# Altium schematic sheet P05_Ethernet.SchDoc
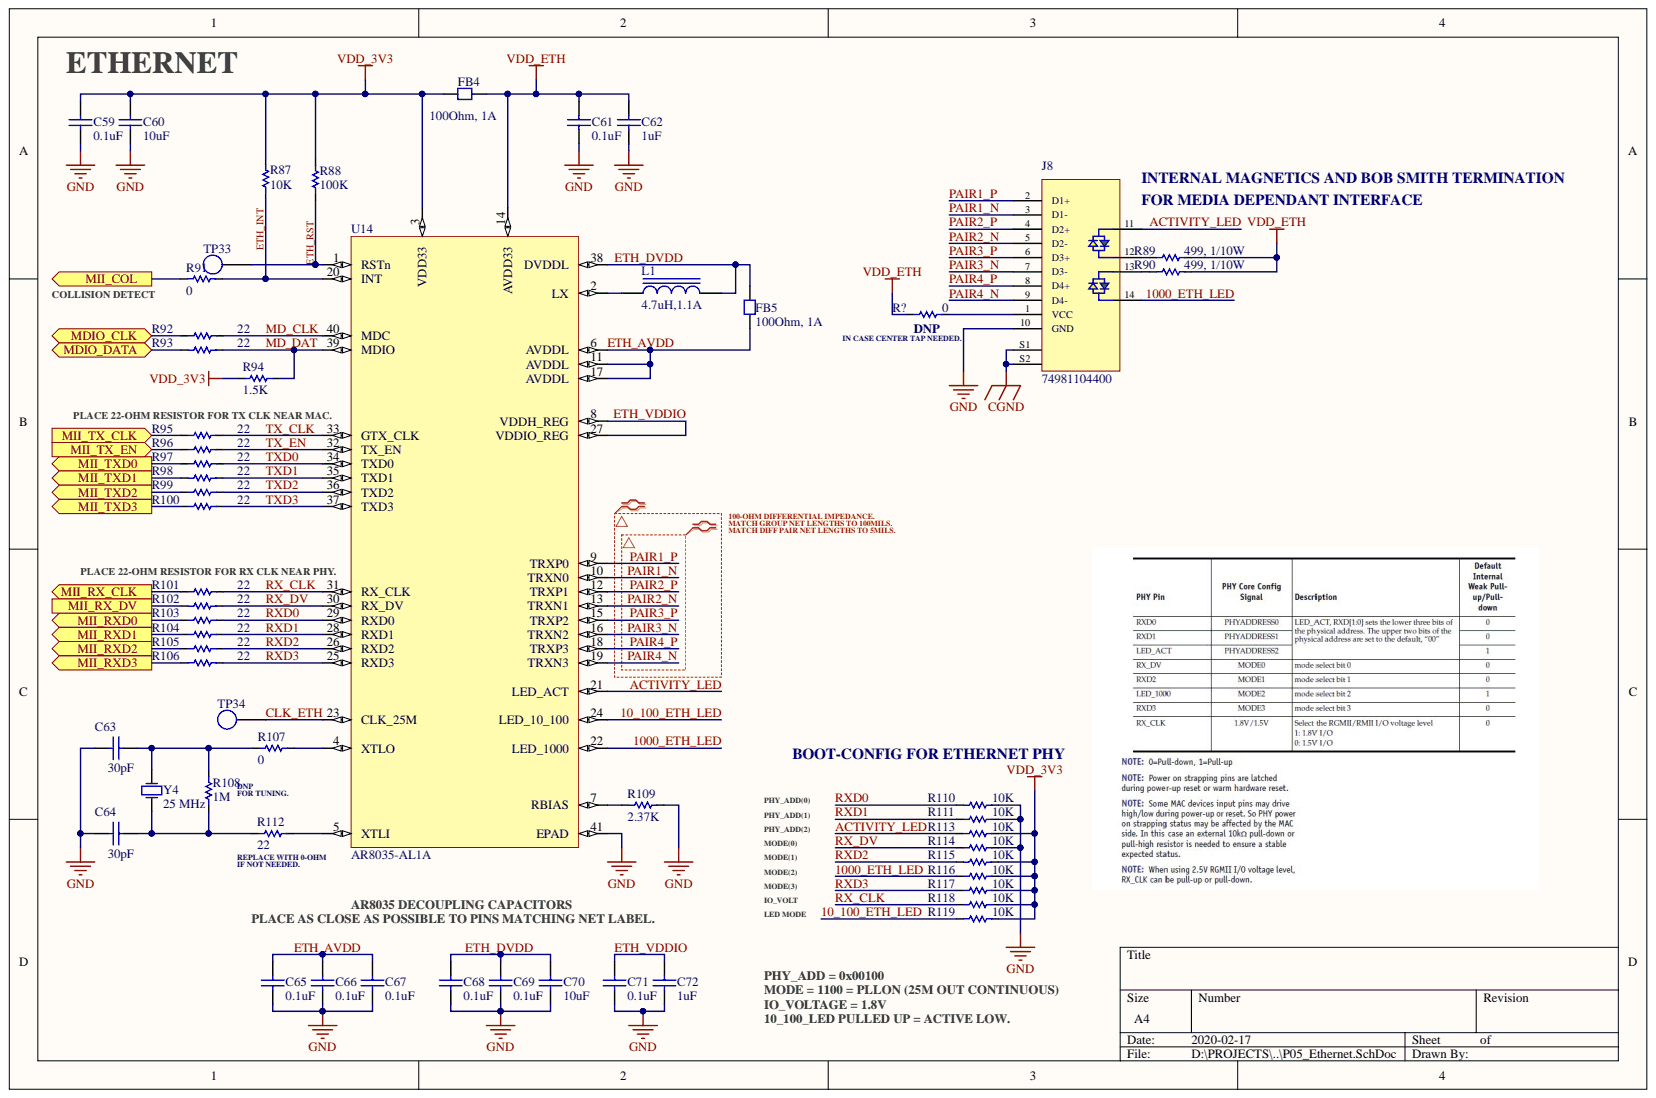
[diagram p0: P05_Ethernet.SchDoc]

## Parts
C59  0.1uF  [CAP_0603]
C60  10uF  [CAP_0603]
C61  0.1uF  [CAP_0603]
C62  1uF  [CAP_0603]
C63  30pF  [CAP_0603]
C64  30pF  [CAP_0603]
C65  0.1uF  [CAP_0603]
C66  0.1uF  [CAP_0603]
C67  0.1uF  [CAP_0603]
C68  0.1uF  [CAP_0603]
C69  0.1uF  [CAP_0603]
C70  10uF  [CAP_0603]
C71  0.1uF  [CAP_0603]
C72  1uF  [CAP_0603]
FB4  100Ohm, 1A  [CAP_0603]
FB5  100Ohm, 1A  [CAP_0603]
J8  74981104400  [74981104400]
L1  4.7uH,1.1A  [IND_1008]
R87  10K  [RES_0603]
R88  100K  [RES_0603]
R89  499, 1/10W  Power Rating: 1/10W  [RES_0603]
R90  499, 1/10W  Power Rating: 1/10W  [RES_0603]
R91  0  [RES_0603]
R92  22  [RES_0603]
R93  22  [RES_0603]
R94  1.5K  [RES_0603]
R95  22  [RES_0603]
R96  22  [RES_0603]
R97  22  [RES_0603]
R98  22  [RES_0603]
R99  22  [RES_0603]
R100  22  [RES_0603]
R101  22  [RES_0603]
R102  22  [RES_0603]
R103  22  [RES_0603]
R104  22  [RES_0603]
R105  22  [RES_0603]
R106  22  [RES_0603]
R107  0  [RES_0603]
R108  1M  [RES_0603]
R109  2.37K  [RES_0603]
R110  10K  [RES_0603]
R111  10K  [RES_0603]
R112  22  [RES_0603]
R113  10K  [RES_0603]
R114  10K  [RES_0603]
R115  10K  [RES_0603]
R116  10K  [RES_0603]
R117  10K  [RES_0603]
R118  10K  [RES_0603]
R119  10K  [RES_0603]
R?  0  [RES_0603]
TP33  TP_SMD  [TP_SMD]  (value not drawn)
TP34  TP_SMD  [TP_SMD]  (value not drawn)
U14  AR8035-AL1A  [QFN-40_5X5MM]
Y4  25 MHz  [7A-24MHz]

## Ports
MDIO_CLK, MDIO_DATA, MII_COL, MII_RX_CLK, MII_RX_DV, MII_RXD0, MII_RXD1, MII_RXD2, MII_RXD3, MII_TX_CLK, MII_TX_EN, MII_TXD0, MII_TXD1, MII_TXD2, MII_TXD3

## Nets
CGND: J8.S1 J8.S2
GND: C59.1 C60.1 C61.1 C62.1 C63.1 C64.1 C65.1 C66.1 C67.1 C68.1 C69.1 C70.1 C71.1 C72.1 J8.10(GND) R109.2 R110.2 R111.2 R114.2 U14.41(EPAD)
VDD_3V3: C59.2 C60.2 FB4.1 R87.2 R88.2 R94.2 R113.2 R115.2 R116.2 R117.2 R118.2 R119.2 U14.3(VDD33)
VDD_ETH: C61.2 C62.2 FB4.2 R89.2 R90.2 R?.1 U14.14(AVDD33)
10_100_ETH_LED: R119.1 U14.24(LED_10_100)
1000_ETH_LED: J8.14 R116.1 U14.22(LED_1000)
ACTIVITY_LED: J8.11 R113.1 U14.21(LED_ACT)
CLK_ETH: TP34.0 U14.23(CLK_25M)
ETH_AVDD: C65.2 C66.2 C67.2 FB5.1 U14.6(AVDDL) U14.11(AVDDL) U14.17(AVDDL)
ETH_DVDD: C68.2 C69.2 C70.2 FB5.2 L1.2 U14.38(DVDDL)
ETH_INT: R87.1 R91.2 U14.20(INT)
ETH_RST: R88.1 TP33.0 U14.1(RSTn)
ETH_VDDIO: C71.2 C72.2 U14.8(VDDH_REG) U14.27(VDDIO_REG)
MD_CLK: R92.2 U14.40(MDC)
MD_DAT: R93.2 R94.1 U14.39(MDIO)
MDIO_CLK: R92.1 ; port MDIO_CLK
MDIO_DATA: R93.1 ; port MDIO_DATA
MII_COL: R91.1 ; port MII_COL
MII_RX_CLK: R101.1 ; port MII_RX_CLK
MII_RX_DV: R102.1 ; port MII_RX_DV
MII_RXD0: R103.1 ; port MII_RXD0
MII_RXD1: R104.1 ; port MII_RXD1
MII_RXD2: R105.1 ; port MII_RXD2
MII_RXD3: R106.1 ; port MII_RXD3
MII_TX_CLK: R95.1 ; port MII_TX_CLK
MII_TX_EN: R96.1 ; port MII_TX_EN
MII_TXD0: R97.1 ; port MII_TXD0
MII_TXD1: R98.1 ; port MII_TXD1
MII_TXD2: R99.1 ; port MII_TXD2
MII_TXD3: R100.1 ; port MII_TXD3
PAIR1_N: J8.3(D1-) U14.10(TRXN0)
PAIR1_P: J8.2(D1+) U14.9(TRXP0)
PAIR2_N: J8.5(D2-) U14.13(TRXN1)
PAIR2_P: J8.4(D2+) U14.12(TRXP1)
PAIR3_N: J8.7(D3-) U14.16(TRXN2)
PAIR3_P: J8.6(D3+) U14.15(TRXP2)
PAIR4_N: J8.9(D4-) U14.19(TRXN3)
PAIR4_P: J8.8(D4+) U14.18(TRXP3)
RX_CLK: R101.2 R118.1 U14.31(RX_CLK)
RX_DV: R102.2 R114.1 U14.30(RX_DV)
RXD0: R103.2 R110.1 U14.29(RXD0)
RXD1: R104.2 R111.1 U14.28(RXD1)
RXD2: R105.2 R115.1 U14.26(RXD2)
RXD3: R106.2 R117.1 U14.25(RXD3)
TX_CLK: R95.2 U14.33(GTX_CLK)
TX_EN: R96.2 U14.32(TX_EN)
TXD0: R97.2 U14.34(TXD0)
TXD1: R98.2 U14.35(TXD1)
TXD2: R99.2 U14.36(TXD2)
TXD3: R100.2 U14.37(TXD3)
NetC63_2: C63.2 R107.1 R108.2 Y4.2
NetC64_2: C64.2 R108.1 R112.1 Y4.1
NetJ8_1: J8.1(VCC) R?.2
NetJ8_12: J8.12 R89.1
NetJ8_13: J8.13 R90.1
NetL1_1: L1.1 U14.2(LX)
NetR107_2: R107.2 U14.4(XTLO)
NetR109_1: R109.1 U14.7(RBIAS)
NetR112_2: R112.2 U14.5(XTLI)
N00356:
N00357:

## Library data of the parts
C59 C61 C65-C69 C71 = C0603C104M4RACTU: CAP CER 0.1UF 16V X7R 0603; Manufacturer: KEMET; Tolerance: 20%; Ceramic Type: X7R; Component Kind: Standard; ComponentLink1Description: Manufacturer; ComponentLink1URL: http://www.we-online.de; ComponentLink2Description: Datasheet; ComponentLink2URL: https://content.kemet.com/datasheets/KEM_C1002_X7R_SMD.pdf; Datasheet: https://content.kemet.com/datasheets/KEM_C1002_X7R_SMD.pdf; Manufacturer Part No.: C0603C104M4RACTU; Mounting Technology: SMD; Package Description: 0603; Package Reference: CAP_0603; Rated Voltage: 16V; Supplier: Digi-Key; Supplier Part No.: 399-1099-1-ND; Value: 0.1uF; Library Name: OSD335-X_Devboard.SchLib
C60 C70 = C0603C106M8PAC7867: CAP CER 10UF 10V X5R 0603; Manufacturer: KEMET; Ceramic Type: X7R; Tolerance: 20%; Component Kind: Standard; ComponentLink1Description: Manufacturer; ComponentLink1URL: http://www.we-online.de; ComponentLink2Description: Datasheet; ComponentLink2URL: https://content.kemet.com/datasheets/KEM_C1002_X7R_SMD.pdf; Datasheet: https://content.kemet.com/datasheets/KEM_C1002_X7R_SMD.pdf; Manufacturer Part No.: C0603C106M8PAC7867; Mounting Technology: SMD; Package Description: 0603; Package Reference: CAP_0603; Rated Voltage: 10V; Supplier: Digi-Key; Supplier Part No.: 399-14945-1-ND; Value: 10uF; Library Name: OSD335-X_Devboard.SchLib
C62 C72 = C0603C105M4RACTU: CAP CER 1UF 16V X7R 0603; Manufacturer: KEMET; Tolerance: 20%; Ceramic Type: X7R; Component Kind: Standard; ComponentLink1Description: Manufacturer; ComponentLink1URL: http://www.we-online.de; ComponentLink2Description: Datasheet; ComponentLink2URL: https://content.kemet.com/datasheets/KEM_C1002_X7R_SMD.pdf; Datasheet: https://content.kemet.com/datasheets/KEM_C1002_X7R_SMD.pdf; Manufacturer Part No.: C0603C105M4RACTU; Mounting Technology: SMD; Package Description: 0603; Package Reference: CAP_0603; Rated Voltage: 16V; Supplier: Digi-Key; Supplier Part No.: 399-11136-1-ND; Value: 1uF; Library Name: OSD335-X_Devboard.SchLib
C63 C64 = 06035A300JAT2A: CAP CER 30PF 50V C0G/NP0 0603; Manufacturer: AVX Corporation; Rated Voltage: 50 VDC; Tolerance: 5%; Component Kind: Standard; ComponentLink1Description: Manufacturer; ComponentLink1URL: http://www.we-online.de; ComponentLink2Description: Datasheet; ComponentLink2URL: https://katalog.we-online.com/pbs/datasheet/865080345012.pdf; Manufacturer Part No.: 06035A300JAT2A; Mounting Technology: SMD; Package Description: 0603; Package Reference: CAP_0603; Supplier: Digi-Key; Supplier Part No.: 478-7917-1-ND; Value: 30 pF; Library Name: OSD335-X_Devboard.SchLib
FB4 FB5 = MPZ1608D101BTA00: FERRITE BEAD 100 OHM 0603 1LN; Manufacturer: TDK Corporation; Component Kind: Standard; Datasheet: https://product.tdk.com/info/en/catalog/datasheets/beads_commercial_power_mpz1608_en.pdf; Manufacturer Part No.: MPZ1608D101BTA00; Mounting Technology: SMD; Package Description: 100 Ohms @ 100MHz 1 Power Line Ferrite Bead 0603 (1608 Metric) 1A 150mOhm; Package Reference: CAP_0603; Supplier: Digi-Key; Supplier Part No.: 445-2988-1-ND; Library Name: OSD335-X_Devboard.SchLib
J8 = 74981104400: WE-RJ45LAN INTEGRATED RJ45 TRANS; Manufacturer: Wurth Elektronik; Component Kind: Standard; ComponentLink1Description: Manufacturer; ComponentLink1URL: http://www.we-online.de; ComponentLink2Description: Datasheet; ComponentLink2URL: https://katalog.we-online.com/pbs/datasheet/74981104400.pdf; Manufacturer Part No.: 74981104400; Mounting Technology: SMD; Package Description: 1 Port RJ45 Magjack Connector Through Hole 10/100/1000 Base-T, AutoMDIX; Package Reference: 7498110440; Supplier: Digi-Key; Supplier Part No.: 732-13940-1-ND; Library Name: OSD335-X_Devboard.SchLib
L1 = CVH252009-4R7M: FIXED IND 4.7UH 1.1A 110MOHM SMD; Manufacturer: Bourns Inc.; Tolerance: 20%; Component Kind: Standard; ComponentLink1Description: Datasheet; ComponentLink1URL: https://www.bourns.com/docs/Product-Datasheets/CVH.pdf; DC Current: 1.1A; DCR: 110 mOhm; Manufacturer Part No.: CVH252009-4R7M; Mounting Technology: SMD; Package Description: 1008; Package Reference: IND_1008; Supplier: Digi-Key; Supplier Part No.: CVH252009-4R7MDKR-ND; Library Name: OSD335-X_Devboard.SchLib
R87 R110 R111 R113-R119 = RC0603FR-0710KL: RES SMD 10K OHM 1% 1/10W 0603; Manufacturer: Yageo; Component Kind: Standard; ComponentLink1Description: Manufacturer Link; ComponentLink1URL: http://www.vishay.com/company/brands/dale/; ComponentLink2Description: Datasheet; ComponentLink2URL: http://www.vishay.com/docs/20035/dcrcwe3.pdf; Datasheet: https://www.yageo.com/upload/media/product/productsearch/datasheet/rchip/PYu-RC_Group_51_RoHS_L_10.pdf; Date: 2020; Manufacturer Part No.: RC0603FR-0710KL; Mounting Technology: SMD; Package Description: 0603; Package Reference: RES_0603; Power Rating: 1/10W; Supplier: Digikey; Supplier Part No.: 311-10.0KHRCT-ND; Tolerance: 1%; Value: 10K; Library Name: OSD335-X_Devboard.SchLib
R88 = RC0603FR-07100KL: RES SMD 100K OHM 1% 1/10W 0603; Manufacturer: Yageo; Component Kind: Standard; ComponentLink1Description: Manufacturer Link; ComponentLink1URL: http://www.vishay.com/company/brands/dale/; ComponentLink2Description: Datasheet; ComponentLink2URL: http://www.vishay.com/docs/20035/dcrcwe3.pdf; Datasheet: https://www.yageo.com/upload/media/product/productsearch/datasheet/rchip/PYu-RC_Group_51_RoHS_L_10.pdf; Date: 2020; Manufacturer Part No.: RC0603FR-07100KL; Mounting Technology: SMD; Package Description: 0603; Package Reference: RES_0603; Power Rating: 1/10W; Supplier: Digikey; Supplier Part No.: 311-100KHRCT-ND; Tolerance: 1%; Value: 100K; Library Name: OSD335-X_Devboard.SchLib
R89 R90 = RC0603FR-07499RL: RES SMD 499 OHM 1% 1/10W 0603; Manufacturer: Yageo; Value: 499; Component Kind: Standard; ComponentLink1Description: Manufacturer Link; ComponentLink1URL: http://www.vishay.com/company/brands/dale/; ComponentLink2Description: Datasheet; ComponentLink2URL: http://www.vishay.com/docs/20035/dcrcwe3.pdf; Datasheet: https://www.yageo.com/upload/media/product/productsearch/datasheet/rchip/PYu-RC_Group_51_RoHS_L_10.pdf; Date: 2020; Manufacturer Part No.: RC0603FR-07499RL; Mounting Technology: SMD; Package Description: 0603; Package Reference: RES_0603; Supplier: Digikey; Supplier Part No.: 311-499HRCT-ND; Tolerance: 1%; Library Name: OSD335-X_Devboard.SchLib
R91 R107 R? = RC0603JR-070RL: RES SMD 0 OHM JUMPER 1/10W 0603; Manufacturer: Yageo; Component Kind: Standard; ComponentLink1Description: Manufacturer Link; ComponentLink1URL: http://www.vishay.com/company/brands/dale/; ComponentLink2Description: Datasheet; ComponentLink2URL: http://www.vishay.com/docs/20035/dcrcwe3.pdf; Datasheet: https://www.yageo.com/upload/media/product/productsearch/datasheet/rchip/PYu-RC_Group_51_RoHS_L_10.pdf; Date: 2020; Manufacturer Part No.: RC0603JR-070RL; Mounting Technology: SMD; Package Description: 0603; Package Reference: RES_0603; Power Rating: 1/10W; Supplier: Digikey; Supplier Part No.: 311-0.0GRCT-ND; Value: 0; Library Name: OSD335-X_Devboard.SchLib
R92 R93 R95-R106 R112 = RC0603JR-0722RL: RES SMD 22 OHM 5% 1/10W 0603; Manufacturer: Yageo; Component Kind: Standard; ComponentLink1Description: Manufacturer Link; ComponentLink1URL: http://www.vishay.com/company/brands/dale/; ComponentLink2Description: Datasheet; ComponentLink2URL: http://www.vishay.com/docs/20035/dcrcwe3.pdf; Datasheet: https://www.yageo.com/upload/media/product/productsearch/datasheet/rchip/PYu-RC_Group_51_RoHS_L_10.pdf; Date: 2020; Manufacturer Part No.: RC0603JR-0722RL; Mounting Technology: SMD; Package Description: 0603; Package Reference: RES_0603; Power Rating: 1/10W; Supplier: Digikey; Supplier Part No.: 311-22GRCT-ND; Tolerance: 5%; Value: 22; Library Name: OSD335-X_Devboard.SchLib
R94 = RC0603FR-071K5L: RES SMD 1.5K OHM 1% 1/10W 0603; Manufacturer: Yageo; Component Kind: Standard; ComponentLink1Description: Manufacturer Link; ComponentLink1URL: http://www.vishay.com/company/brands/dale/; ComponentLink2Description: Datasheet; ComponentLink2URL: http://www.vishay.com/docs/20035/dcrcwe3.pdf; Datasheet: https://www.yageo.com/upload/media/product/productsearch/datasheet/rchip/PYu-RC_Group_51_RoHS_L_10.pdf; Date: 2020; Manufacturer Part No.: RC0603FR-071K5L; Mounting Technology: SMD; Package Description: 0603; Package Reference: RES_0603; Power Rating: 1/10W; Supplier: Digikey; Supplier Part No.: 311-1.50KHRCT-ND; Tolerance: 1%; Value: 1.5K; Library Name: OSD335-X_Devboard.SchLib
R108 = RC0603JR-071ML: RES SMD 1M OHM 5% 1/10W 0603; Manufacturer: Yageo; Component Kind: Standard; ComponentLink1Description: Manufacturer Link; ComponentLink1URL: http://www.vishay.com/company/brands/dale/; ComponentLink2Description: Datasheet; ComponentLink2URL: http://www.vishay.com/docs/20035/dcrcwe3.pdf; Datasheet: https://www.yageo.com/upload/media/product/productsearch/datasheet/rchip/PYu-RC_Group_51_RoHS_L_10.pdf; Date: 2020; Manufacturer Part No.: RC0603JR-071ML; Mounting Technology: SMD; Package Description: 0603; Package Reference: RES_0603; Power Rating: 1/10W; Supplier: Digikey; Supplier Part No.: 311-1.0MGRCT-ND; Tolerance: 5%; Value: 1M; Library Name: OSD335-X_Devboard.SchLib
R109 = RC0603FR-072K37L: RES SMD 2.37K OHM 1% 1/10W 0603; Manufacturer: Yageo; Component Kind: Standard; ComponentLink1Description: Manufacturer Link; ComponentLink1URL: http://www.vishay.com/company/brands/dale/; ComponentLink2Description: Datasheet; ComponentLink2URL: http://www.vishay.com/docs/20035/dcrcwe3.pdf; Datasheet: https://www.yageo.com/upload/media/product/productsearch/datasheet/rchip/PYu-RC_Group_51_RoHS_L_10.pdf; Date: 2020; Manufacturer Part No.: RC0603FR-072K37L; Mounting Technology: SMD; Package Description: 0603; Package Reference: RES_0603; Power Rating: 1/10W; Supplier: Digikey; Supplier Part No.: 311-2.37KHRCT-ND; Tolerance: 1%; Value: 2.37K; Library Name: OSD335-X_Devboard.SchLib
U14 = AR8035-AL1A: IC ETHERNET TRANSCEIVER FULL 1/1 GIGABIT 40QFN; Manufacturer: Qualcomm; Component Kind: Standard; Manufacturer Part No.: AR8035-AL1A; Mounting Technology: SMD; Package Description: 40-WFQFN Exposed Pad; Package Reference: QFN-40_5x5MM; Supplier: Digi-Key; Supplier Part No.: AR8035-AL1A-ND; Library Name: OSD335-X_Devboard.SchLib
Y4 = 7A-25.000MAAJ-T: CRYSTAL 25.0000MHZ 18PF SMD; Manufacturer: TXC CORPORATION; Component Kind: Standard; ComponentLink1Description: Manufacturer Link; ComponentLink1URL: http://www.ecsxtal.com/; ComponentLink2Description: Data Sheet; Date: 2020; Manufacturer Part No.: 7A-25.000MAAJ-T; Mounting Technology: SMD; Package Description: 7A-24MHz; Package Reference: 7A-24MHz; Supplier: Digikey; Supplier Part No.: 887-1092-1-ND; Value: 25 MHz; Library Name: OSD335-X_Devboard.SchLib
TP33 TP34 = TP_SMD: Component Kind: Standard; Library Name: OSD335-X_Devboard.SchLib
